# Altium schematic sheet 07 RS485.SchDoc
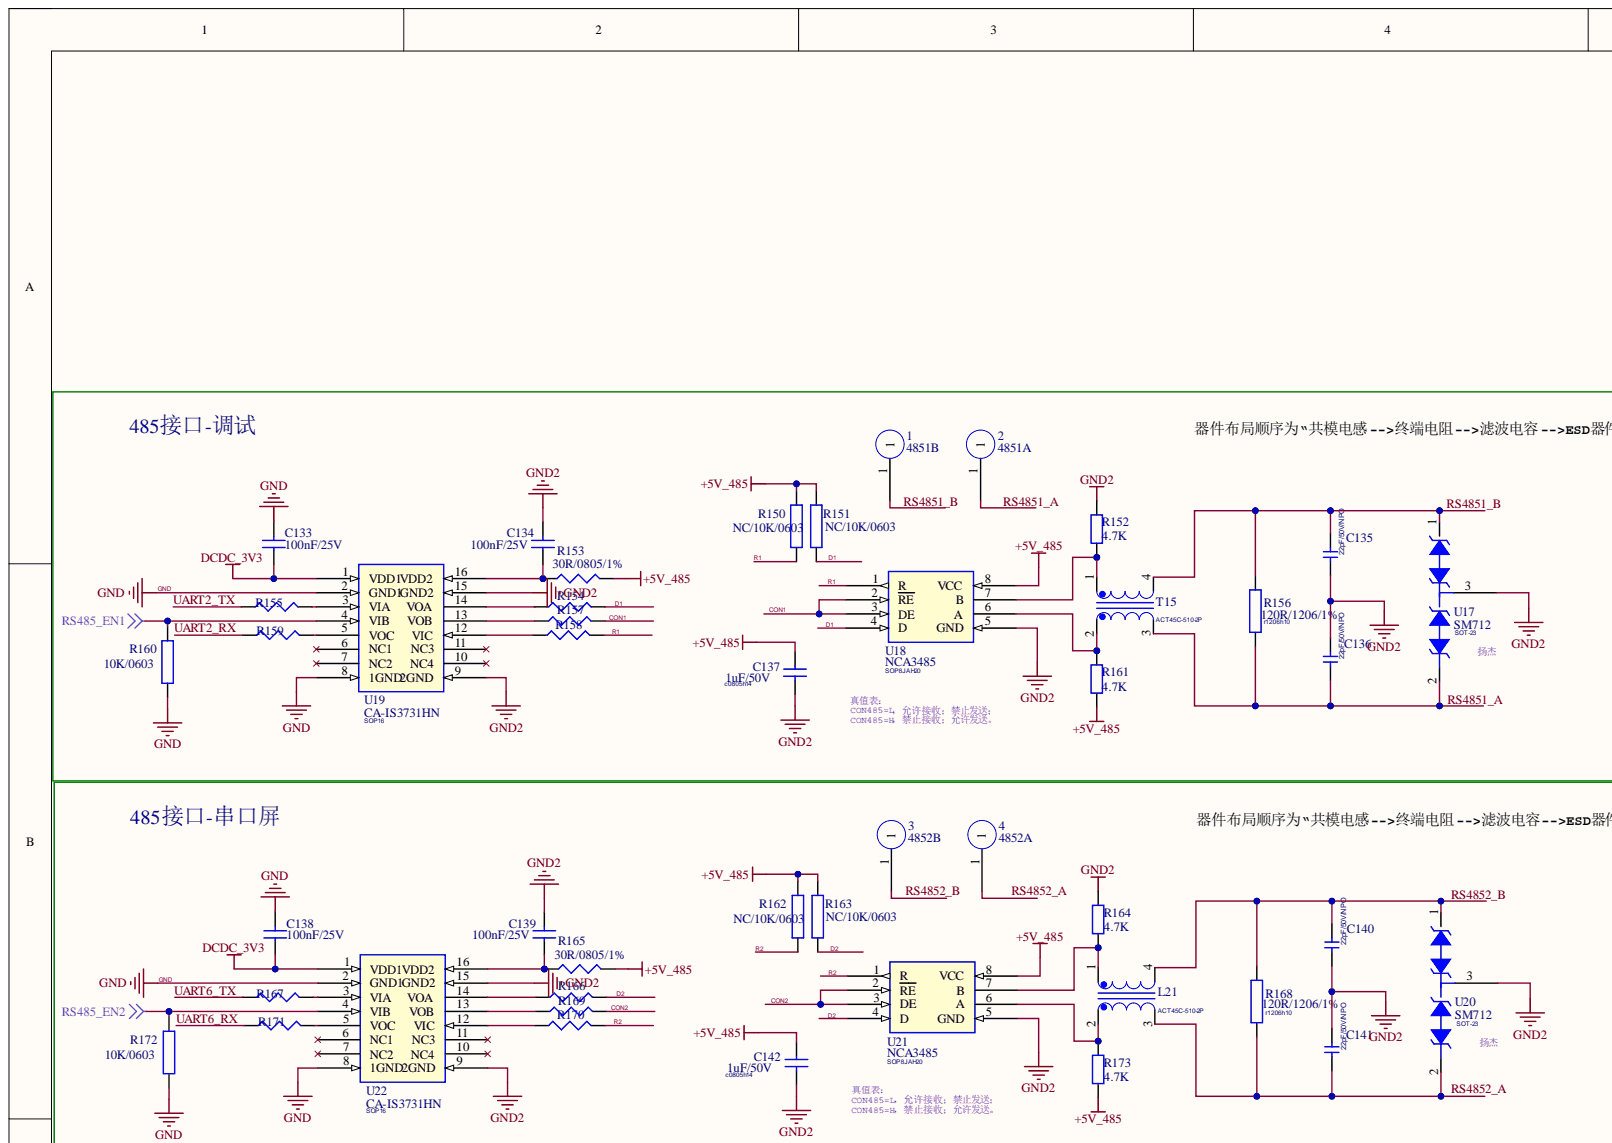
[diagram p0: 07 RS485.SchDoc, top left]
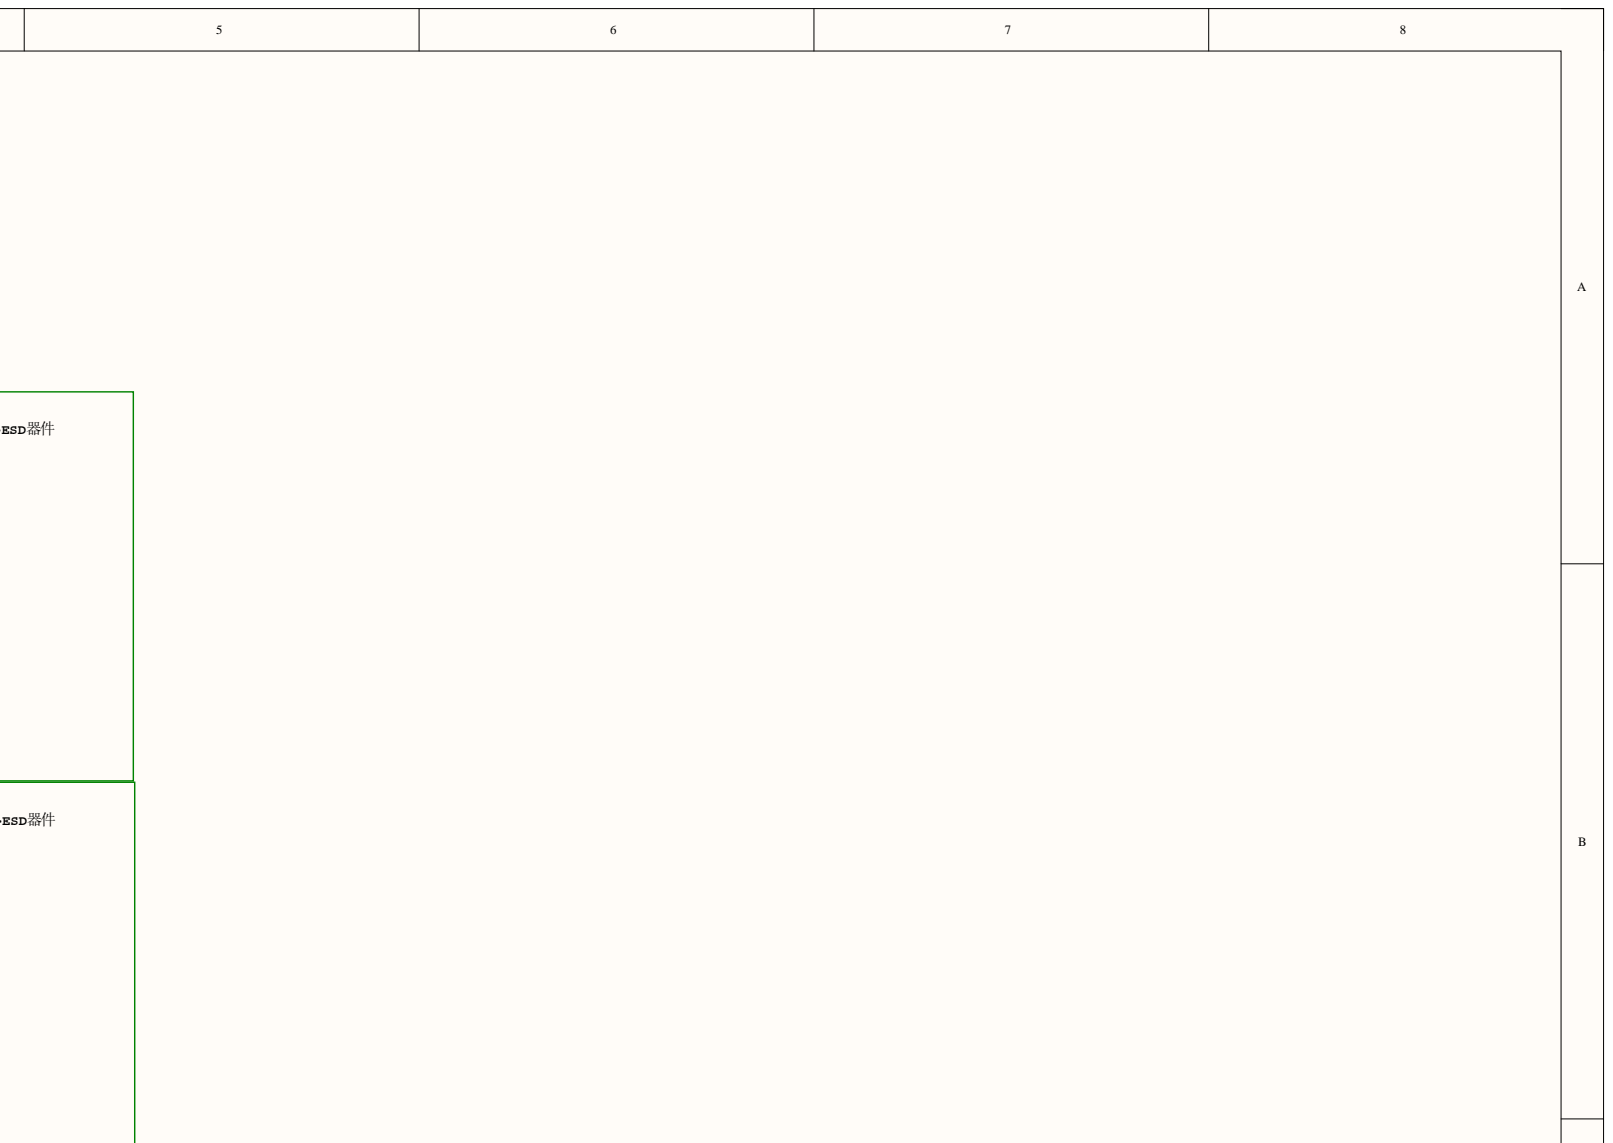
[diagram p1: 07 RS485.SchDoc, top right]
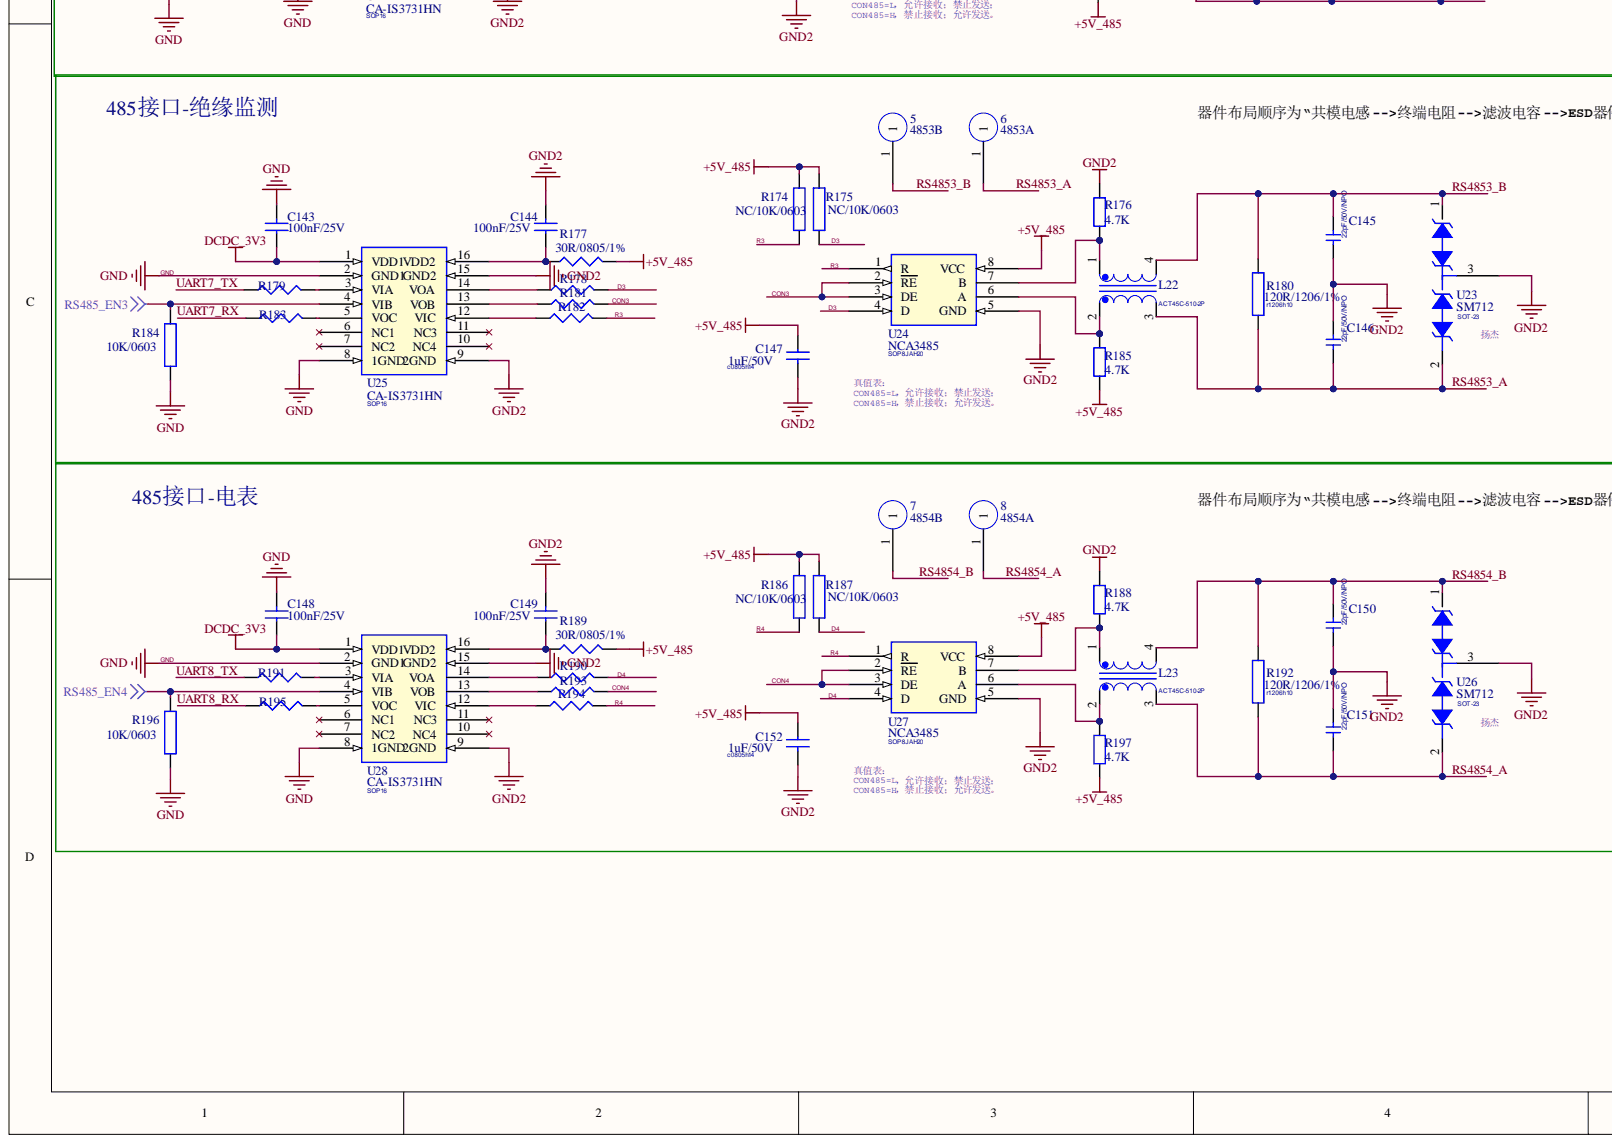
[diagram p2: 07 RS485.SchDoc, bottom left]
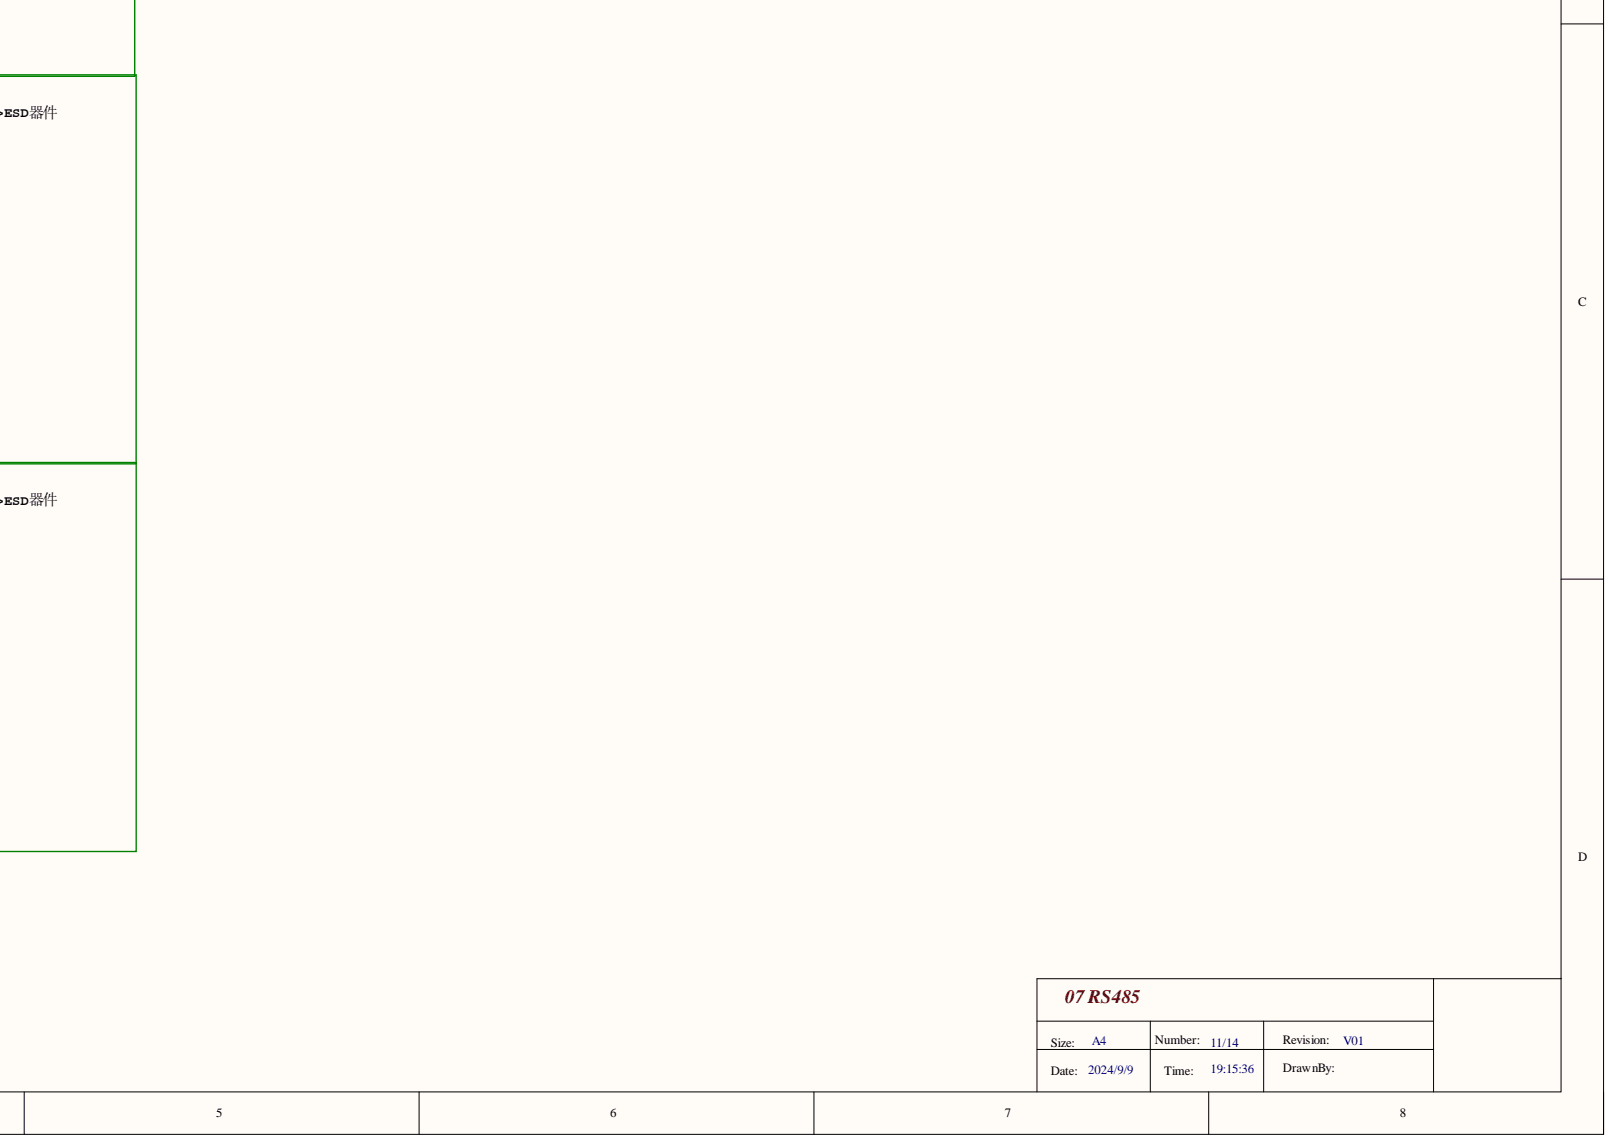
[diagram p3: 07 RS485.SchDoc, bottom right]

## Parts
1  4851B  [TP12]
2  4851A  [TP12]
3  4852B  [TP12]
4  4852A  [TP12]
5  4853B  [TP12]
6  4853A  [TP12]
7  4854B  [TP12]
8  4854A  [TP12]
C133  100nF/25V  [c0603h9]
C134  100nF/25V  [c0603h9]
C135  22pF/50V/NPO  [C0603]
C136  22pF/50V/NPO  [C0603]
C137  1uF/50V  [c0805h14]
C138  100nF/25V  [c0603h9]
C139  100nF/25V  [c0603h9]
C140  22pF/50V/NPO  [C0603]
C141  22pF/50V/NPO  [C0603]
C142  1uF/50V  [c0805h14]
C143  100nF/25V  [c0603h9]
C144  100nF/25V  [c0603h9]
C145  22pF/50V/NPO  [C0603]
C146  22pF/50V/NPO  [C0603]
C147  1uF/50V  [c0805h14]
C148  100nF/25V  [c0603h9]
C149  100nF/25V  [c0603h9]
C150  22pF/50V/NPO  [C0603]
C151  22pF/50V/NPO  [C0603]
C152  1uF/50V  [c0805h14]
L21  ACT45C-510-2P  [ACT45C-510-2P]
L22  ACT45C-510-2P  [ACT45C-510-2P]
L23  ACT45C-510-2P  [ACT45C-510-2P]
R150  NC/10K/0603  [r0603h10]
R151  NC/10K/0603  [r0603h10]
R152  4.7K  [R0603]
R153  30R/0805/1%  [r0805h6]
R154  30R/0805/1%  [r0805h6]
R155  30R/0805/1%  [r0805h6]
R156  120R/1206/1%  [r1206h10]
R157  30R/0805/1%  [r0805h6]
R158  30R/0805/1%  [r0805h6]
R159  30R/0805/1%  [r0805h6]
R160  10K/0603  [r0603h10]
R161  4.7K  [R0603]
R162  NC/10K/0603  [r0603h10]
R163  NC/10K/0603  [r0603h10]
R164  4.7K  [R0603]
R165  30R/0805/1%  [r0805h6]
R166  30R/0805/1%  [r0805h6]
R167  30R/0805/1%  [r0805h6]
R168  120R/1206/1%  [r1206h10]
R169  30R/0805/1%  [r0805h6]
R170  30R/0805/1%  [r0805h6]
R171  30R/0805/1%  [r0805h6]
R172  10K/0603  [r0603h10]
R173  4.7K  [R0603]
R174  NC/10K/0603  [r0603h10]
R175  NC/10K/0603  [r0603h10]
R176  4.7K  [R0603]
R177  30R/0805/1%  [r0805h6]
R178  30R/0805/1%  [r0805h6]
R179  30R/0805/1%  [r0805h6]
R180  120R/1206/1%  [r1206h10]
R181  30R/0805/1%  [r0805h6]
R182  30R/0805/1%  [r0805h6]
R183  30R/0805/1%  [r0805h6]
R184  10K/0603  [r0603h10]
R185  4.7K  [R0603]
R186  NC/10K/0603  [r0603h10]
R187  NC/10K/0603  [r0603h10]
R188  4.7K  [R0603]
R189  30R/0805/1%  [r0805h6]
R190  30R/0805/1%  [r0805h6]
R191  30R/0805/1%  [r0805h6]
R192  120R/1206/1%  [r1206h10]
R193  30R/0805/1%  [r0805h6]
R194  30R/0805/1%  [r0805h6]
R195  30R/0805/1%  [r0805h6]
R196  10K/0603  [r0603h10]
R197  4.7K  [R0603]
T15  ACT45C-510-2P  [ACT45C-510-2P]
U17  SM712  [SOT-23]
U18  NCA3485  PCB Footprint: SOP8JAH20  [SOIC-8_150mil]
U19  CA-IS3731HN  PCB Footprint: SOP16  [SOIC-16_150mil]
U20  SM712  [SOT-23]
U21  NCA3485  PCB Footprint: SOP8JAH20  [SOIC-8_150mil]
U22  CA-IS3731HN  PCB Footprint: SOP16  [SOIC-16_150mil]
U23  SM712  [SOT-23]
U24  NCA3485  PCB Footprint: SOP8JAH20  [SOIC-8_150mil]
U25  CA-IS3731HN  PCB Footprint: SOP16  [SOIC-16_150mil]
U26  SM712  [SOT-23]
U27  NCA3485  PCB Footprint: SOP8JAH20  [SOIC-8_150mil]
U28  CA-IS3731HN  PCB Footprint: SOP16  [SOIC-16_150mil]

## Nets
+5V_485: C137.1 C142.1 C147.1 C152.1 R150.1 R151.1 R153.2 R161.2 R162.1 R163.1 R165.2 R173.2 R174.1 R175.1 R177.2 R185.2 R186.1 R187.1 R189.2 R197.2 U18.8(VCC) U21.8(VCC) U24.8(VCC) U27.8(VCC)
DCDC_3V3: C133.1 C138.1 C143.1 C148.1 U19.1(VDD1) U22.1(VDD1) U25.1(VDD1) U28.1(VDD1)
GND2: C134.2 C135.1 C136.2 C137.2 C139.2 C140.1 C141.2 C142.2 C144.2 C145.1 C146.2 C147.2 C149.2 C150.1 C151.2 C152.2 R152.1 R164.1 R176.1 R188.1 U17.3 U18.5(GND) U19.9(2GND) U19.15(GND2) U20.3 U21.5(GND) U22.9(2GND) U22.15(GND2) U23.3 U24.5(GND) U25.9(2GND) U25.15(GND2) U26.3 U27.5(GND) U28.9(2GND) U28.15(GND2)
RS485_EN1: R160.1 U19.4(VIB)
RS485_EN2: R172.1 U22.4(VIB)
RS485_EN3: R184.1 U25.4(VIB)
RS485_EN4: R196.1 U28.4(VIB)
CON1: R157.2 U18.2(RE) U18.3(DE)
CON2: R169.2 U21.2(RE) U21.3(DE)
CON3: R181.2 U24.2(RE) U24.3(DE)
CON4: R193.2 U27.2(RE) U27.3(DE)
D1: R151.2 R154.2 U18.4(D)
D2: R163.2 R166.2 U21.4(D)
D3: R175.2 R178.2 U24.4(D)
D4: R187.2 R190.2 U27.4(D)
GND: C133.2 C138.2 C143.2 C148.2 R160.2 R172.2 R184.2 R196.2 U19.2(GND1) U19.8(1GND) U22.2(GND1) U22.8(1GND) U25.2(GND1) U25.8(1GND) U28.2(GND1) U28.8(1GND)
R1: R150.2 R158.2 U18.1(R)
R2: R162.2 R170.2 U21.1(R)
R3: R174.2 R182.2 U24.1(R)
R4: R186.2 R194.2 U27.1(R)
RS4851_A: 2.1 C136.1 R156.2 T15.3 U17.2
RS4851_B: 1.1 C135.2 R156.1 T15.4 U17.1
RS4852_A: 4.1 C141.1 L21.3 R168.2 U20.2
RS4852_B: 3.1 C140.2 L21.4 R168.1 U20.1
RS4853_A: 6.1 C146.1 L22.3 R180.2 U23.2
RS4853_B: 5.1 C145.2 L22.4 R180.1 U23.1
RS4854_A: 8.1 C151.1 L23.3 R192.2 U26.2
RS4854_B: 7.1 C150.2 L23.4 R192.1 U26.1
UART2_RX: R159.1
UART2_TX: R155.1
UART6_RX: R171.1
UART6_TX: R167.1
UART7_RX: R183.1
UART7_TX: R179.1
UART8_RX: R195.1
UART8_TX: R191.1
NetC134_1: C134.1 R153.1 U19.16(VDD2)
NetC139_1: C139.1 R165.1 U22.16(VDD2)
NetC144_1: C144.1 R177.1 U25.16(VDD2)
NetC149_1: C149.1 R189.1 U28.16(VDD2)
NetL21_1: L21.1 R164.2 U21.7(B)
NetL21_2: L21.2 R173.1 U21.6(A)
NetL22_1: L22.1 R176.2 U24.7(B)
NetL22_2: L22.2 R185.1 U24.6(A)
NetL23_1: L23.1 R188.2 U27.7(B)
NetL23_2: L23.2 R197.1 U27.6(A)
NetR152_2: R152.2 T15.1 U18.7(B)
NetR154_1: R154.1 U19.14(VOA)
NetR155_2: R155.2 U19.3(VIA)
NetR157_1: R157.1 U19.13(VOB)
NetR158_1: R158.1 U19.12(VIC)
NetR159_2: R159.2 U19.5(VOC)
NetR161_1: R161.1 T15.2 U18.6(A)
NetR166_1: R166.1 U22.14(VOA)
NetR167_2: R167.2 U22.3(VIA)
NetR169_1: R169.1 U22.13(VOB)
NetR170_1: R170.1 U22.12(VIC)
NetR171_2: R171.2 U22.5(VOC)
NetR178_1: R178.1 U25.14(VOA)
NetR179_2: R179.2 U25.3(VIA)
NetR181_1: R181.1 U25.13(VOB)
NetR182_1: R182.1 U25.12(VIC)
NetR183_2: R183.2 U25.5(VOC)
NetR190_1: R190.1 U28.14(VOA)
NetR191_2: R191.2 U28.3(VIA)
NetR193_1: R193.1 U28.13(VOB)
NetR194_1: R194.1 U28.12(VIC)
NetR195_2: R195.2 U28.5(VOC)

Not connected: U19 6(NC1) 7(NC2) 10(NC4) 11(NC3); U22 6(NC1) 7(NC2) 10(NC4) 11(NC3); U25 6(NC1) 7(NC2) 10(NC4) 11(NC3); U28 6(NC1) 7(NC2) 10(NC4) 11(NC3)

## Library data of the parts
1-8 = PAD: Component Kind: Standard; PCB Footprint: TP12
C133 C134 C137-C139 C142-C144 C147-C149 C152 = 30pF-X7R-50V-0805_2_Dup2: Tolerance: 50V,X7R,+/-10%; Component Kind: Standard
  C133 C134 C138 C139 C143 C144 C148 C149: PCB Footprint: c0603h9
  C137 C142 C147 C152: PCB Footprint: c0805h14
C135 C136 C140 C141 C145 C146 C150 C151 = c_1: PCB Footprint: c0603h9; PSpiceTemplate: C^@REFDES %1 %2 ?TOLERANCE|C^@REFDES| @VALUE ?IC/IC=@IC/ ?TC/TC=@TC/ ?TOLERANCE|\n.model C^@REFDES CAP C=1 DEV=@TOLERANCE%|; Component Kind: Standard; Description: 22pF/50V/NPO; Simulation: c_1; Value: =Value
L21-L23 T15 = TRNSFMR TY-402P_5: Component Kind: Standard; Description: ACT45C-510-2P; PCB Footprint: ACT45C-510-2P
R150 R151 R156 R160 R162 R163 R168 R172 R174 R175 R180 R184 R186 R187 R192 R196 = R_2: Component Kind: Standard
  R150 R151 R160 R162 R163 R172 R174 R175 R184 R186 R187 R196: PCB Footprint: r0603h10
  R156 R168 R180 R192: PCB Footprint: r1206h10
R152 R161 R164 R173 R176 R185 R188 R197 = 4.: 片状电阻器－1/8W－4.7KΩ1%－0805; MPN: RC0805FR-074K7L; ERP code: E00000273; Component Kind: Standard; Library Name: Component Library.IntLib; Signal Integrity: Res; Simulation: RESISTOR; Value: 4.7K
R153-R155 R157-R159 R165-R167 R169-R171 R177-R179 R181-R183 R189-R191 R193-R195 = RESISTOR_1: Component Kind: Standard; PCB Footprint: r0805h6
U17 U20 U23 U26 = DIODE ZENER_11_Dup1: Component Kind: Standard; PCB Footprint: SOT-23
U18 U21 U24 U27 = TJA1040T_9: Component Kind: Standard
U19 U22 U25 U28 = TJA1040T_30: Component Kind: Standard
